# Altium schematic sheet H-Bridge Driver.SchDoc("H-Bridge Driver")
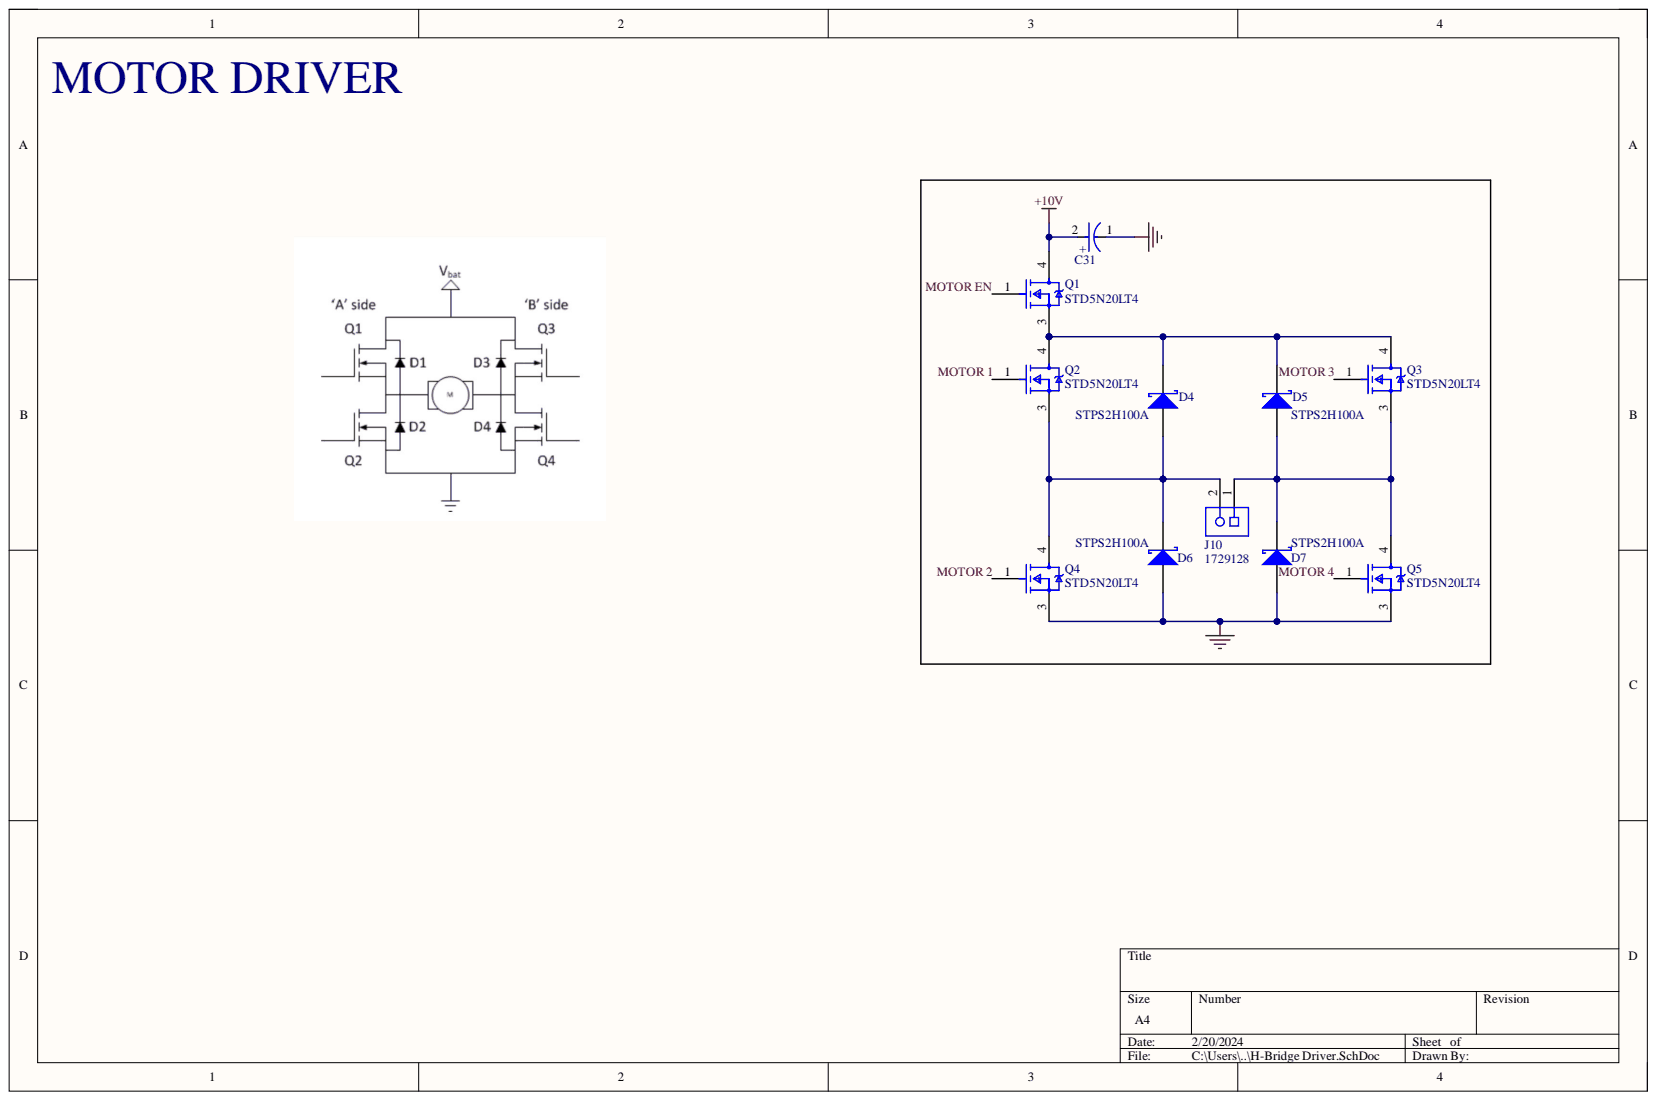
[diagram p0: H-Bridge Driver.SchDoc]

## Parts
C31  EEE-1AA102P  [FP-SG-MFG]  (value not drawn)
D4  STPS2H100A  [STM-SMA-2_V]
D5  STPS2H100A  [STM-SMA-2_V]
D6  STPS2H100A  [STM-SMA-2_V]
D7  STPS2H100A  [STM-SMA-2_V]
J10  1729128  [PHOE-1729128-2_V]
Q1  STD5N20LT4  [FP-DPAK-P032P-MFG]
Q2  STD5N20LT4  [FP-DPAK-P032P-MFG]
Q3  STD5N20LT4  [FP-DPAK-P032P-MFG]
Q4  STD5N20LT4  [FP-DPAK-P032P-MFG]
Q5  STD5N20LT4  [FP-DPAK-P032P-MFG]

## Nets
+10V: C31.2 Q1.4
GND: C31.1 D6.1 D7.1 Q4.3 Q5.3
MOTOR 1: Q2.1
MOTOR 2: Q4.1
MOTOR 3: Q3.1
MOTOR 4: Q5.1
MOTOR EN: Q1.1
NetD4_1: D4.1 D6.2 J10.2 Q2.3 Q4.4
NetD4_2: D4.2 D5.2 Q1.3 Q2.4 Q3.4
NetD5_1: D5.1 D7.2 J10.1 Q3.3 Q5.4

## Library data of the parts
C31 = CMP-00287-55501125-1: EEE-1AA102P Aluminum Electrolytic Capacitor, 1000 uF, 10 V, ± 20%, 2000 hours @ 85°C, Radial Can - SMD; Capacitance: 1mF; Leakage Current: 3µA; Ripple Current: 580mA; Voltage: 10V; Case/Package: Radial; Component Kind: Standard; Contact Plating: Tin; Diameter: 10mm; Height: 10.2mm; Height - Seated (Max): 10.2108mm; Lead Free: Lead Free; Length: 10.5mm; Library Name: Altium Content Vault; Life (Hours): 2000hours; Lifecycle Status: Production; Max Operating Temperature: 85°C; Min Operating Temperature: -40°C; Mount: PCB, Surface Mount; NexarPartId: 55501125; Packaging: Cut Tape; Radiation Hardening: No; REACH SVHC: Unknown; Ripple Current (AC): 580mA; RoHS: Compliant; Schedule B: 8532220020, 8532220020; Termination: SMD/SMT; Tolerance: 20%; Voltage Rating: 10V; Voltage Rating (DC): 10V
D4-D7 = CMP-2000-07175-1: Power Schottky Rectifier, 2 A, 100 V, 2-Pin SMD (SMA), RoHS, Tape and Reel; Manufacturer: STMicroelectronics; Current - Average Rectified (Io): 2A; Current - Reverse Leakage @ Vr: 1µA@100V; Online Catalog: SchottkyDiode; Other Names: 497-3758-6; Other Related Documents: STPS2H100ViewAllSpecifications; Speed: FastRecovery=200mA(Io); Voltage - DC Reverse (Vr) (Max): 100V; Voltage - Forward (Vf) (Max) @ If: 790mV@2A; Capacitance @ Vr, F: -; Category: DiscreteSemiconductorProducts; Component Kind: Standard; Datasheet URL: http://www.st.com/content/ccc/resource/technical/document/datasheet/0a/8b/df/ef/15/72/4f/38/CD00001548.pdf/files/CD00001548.pdf/jcr:content/translations/en.CD00001548.pdf; Datasheet Version: 06/2013; Diode Type: Schottky; Family: Diodes,Rectifiers-Single; Library Name: Altium Content Vault; Manufacturer URL: http://www.st.com/; Mounting Technology: SurfaceMount; Mounting Type: SurfaceMount; Operating Temperature - Junction: 175°C(Max); Package / Case: DO-214AC,SMA; Package Description: 2-Pin Surface Mount Device, Body 4.275 x 2.575 mm; Package Reference: SMA; Package URL: http://www.st.com/st-web-ui/static/active/en/resource/technical/document/datasheet/CD00283518.pdf; Packaging: Digi-Reel®; Reverse Recovery Time (trr): -; Series: -; Standard Package: 1; Supplier Device Package: SMA(DO-214AC)
J10 = CMP-2000-05815-1: PCB Terminal Block, 13.5 A, 400 V, 2-Pin THD, RoHS, Bulk; Manufacturer: Phoenix Contact; Current Rating: 13500mA; No. of Positions: 2; No. of Rows: 1; Package Version: 03/2015; Pitch: 5.08mm; Voltage Rating: 400V; Component Kind: Standard; Datasheet URL: https://datasheet.ciiva.com/16046/1729128-16046181.pdf; Datasheet Version: 12/2013; Library Name: Altium Content Vault; Manufacturer URL: http://www.phoenixcontact.com/; Mounting Technology: ThroughHole; Orientation: Horizontal; Package Description: 2-Pin Through Hole Device, Body 8.1 x 10.16 mm, Pitch 5.08 mm; Package Reference: 1729128-2; Package URL: https://www.phoenixcontact.com/online/portal/pi/pxc/product_detail_page/!ut/p/b1/3VdJjqNKFDxLH8AFycySyWY2ozFsEPOMMQaDOX1XedGL_1Vdm5YXJleknhSKeBkvI6EA8ncAo3AYoDhBQGco6KN7VURTdemj9us_IEKEZo_YHiPAEWEFmDGOHMORkqKYCORBZxgL7ZoaZYcbFFUZ3fqxSY6GOuxydWUuHqz1ynmMFygu583Bob0Ewr6Sd_l-5m-kAueHiB0jpRwZwe58wwtkpxtMYd_LmGcDRU02dRNEyWt6Y2ti5pSyJ_reIkzIulrqApdnbT11V5jnCKbYNCvhEM1MCpPByat_X379-iThf08CI5GfOPqfBeS3BRoBOdD55AKVv0iLwCMq-2BrzXWSGRH7pUGD9uwXQjiph3xL0DKQwdGa0JImiIOmBNOestqgwTHSSs2oOep-78apyXQBvNi8ZLaFjvjWvcaUEBu0MC6Wbe-d6mB0FAebo2lUWinqYaZs5DAYzV0RP9yitUjnGJ0iI9xhZkT42OCQNUJJhkgWINNQLP2JFP6OpMg3JCVg70jqHT0lvKOnhHf0lPuOnnLf0VPuWx6_dxwUIvwk5WMkT0kLL1mh61l2yZVSzG3pYV_bqo-mj6uMKwKwdnnW8Jh5RTOJzMOA5c-eJDaZQw4mTMPH02PvS_ZAqNVjVTo2WyYLkwH_KU1mmmG5kjRhDqPXLwwVHvVOjCM60-fpXvTDfMGW1PVFVS1r4e4yVDk4ZdNbkUHvqPqQSeian4UD39fNKmachqdJ1NJbyk8rXT9mXTvPk3oE5meulKGgiruPJek-4I9hTT6G8ZLOyRSm2RRVbThERQad5OS28hdt4YW4vG0stgYYzsWsK4_7y6DZMjJSCqri1kyiZR5vD7pwIrNR7QwPHTcLTI5qT7eRlpHrdGiupYlqQDJ7zy_CND8fWWBoLRXxk1RVcXiBQwbTD4Xi7KL-FuO94t9xaqweXzH; Packing: Bulk; PartNumber: 1729128; RoHS: TRUE
Q1-Q5 = CMP-12198-000019-1: MOSFET N-CH 200V 5A DPAK; Manufacturer: STMicroelectronics; Depletion Mode: False; Drain To Source Resistance: 0.65Ω; Gate Charge At Vgs: 5nC @ 5V; Gate To Source Voltage: 20V; Input Capacitace At Vds: 242pF @ 25V; Max Forward Diode Voltage: 1.5V; Max Junction Temp: +150°C; Number Of Channels: 1; Power Dissipation: 33W; Pulse Drain Current: 20A; Reverse Recovery Charge: 237nC; Reverse Recovery Time: 93ns; Rthja Max: 100°C/W; Standoff Height: 0.03mm; Threshold Vgs Max: 2.5V; Threshold Vgs Min: 1V; Transconductance: 6.5S; Turn Off Delay Time: 14ns; Turn On Delay Time: 11.5ns; Automotive: No; Category: Trans; Component Kind: Standard; ComponentLink2Description: Datasheet; ComponentLink2URL: https://www.st.com/resource/en/datasheet/std5n20l.pdf; Continuous Drain Current: 5A; Device Class L1: Discrete Semiconductors; Device Class L2: Transistors; Device Class L3: MOSFETs; Digikey Description: MOSFET N-CH 200V 5A DPAK; Drain To Source Breakdown Voltage: 200V; Drain To Source Voltage: 200V; Height: 2.63mm; Lead Free: Yes; Library Name: Altium Content Vault; Manufacturer Part Number: STD5N20LT4; Mouser Description: MOSFET Transistor, N Channel, 2.5 A, 200 V, 650 mohm, 5 V, 2.5 V RoHS Compliant: Yes; Number Of N Channels: 1; Octopart: https://octopart.com/std5n20lt4-stmicroelectronics-335491; Package: DPAK-P032P; RoHS: TRUE; Temperature: -55°C to +150°C; Temperature Range High: +150°C; Temperature Range Low: -55°C
